# Altium schematic sheet Controller.SchDoc
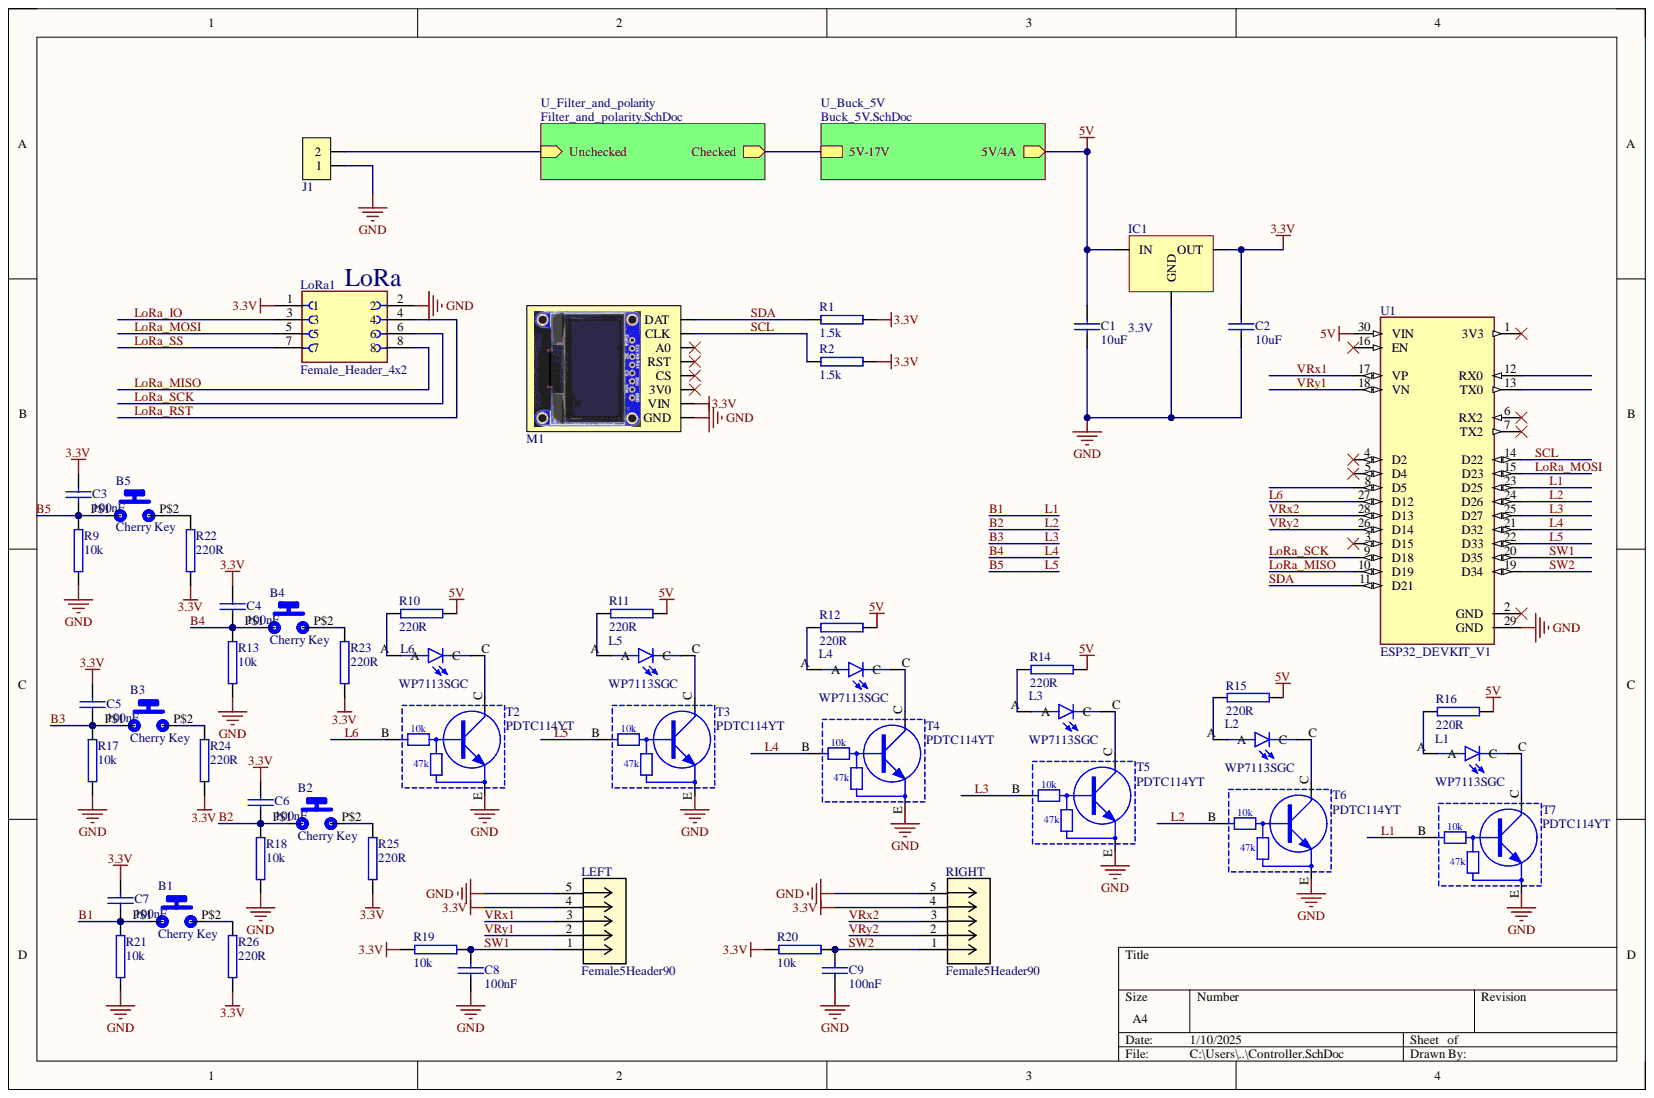
[diagram p0: Controller.SchDoc]

## Parts
B1  Cherry Key  MF: Cherry  [CHERRY_MX1A-11NN_SWITCH]
B2  Cherry Key  MF: Cherry  [CHERRY_MX1A-11NN_SWITCH]
B3  Cherry Key  MF: Cherry  [CHERRY_MX1A-11NN_SWITCH]
B4  Cherry Key  MF: Cherry  [CHERRY_MX1A-11NN_SWITCH]
B5  Cherry Key  MF: Cherry  [CHERRY_MX1A-11NN_SWITCH]
C1  value 10uF  [0603]  (hidden comment: C 10uF 0603)
C2  value 10uF  [0603]  (hidden comment: C 10uF 0603)
C3  value 100nF  [0603]  (hidden comment: C 100nF 0603)
C4  value 100nF  [0603]  (hidden comment: C 100nF 0603)
C5  value 100nF  [0603]  (hidden comment: C 100nF 0603)
C6  value 100nF  [0603]  (hidden comment: C 100nF 0603)
C7  value 100nF  [0603]  (hidden comment: C 100nF 0603)
C8  value 100nF  [0603]  (hidden comment: C 100nF 0603)
C9  value 100nF  [0603]  (hidden comment: C 100nF 0603)
IC1  Voltage Out: 3.3V  [SOT223]  (hidden comment: LDO_1A_3V3)
J1  2Pin_Female  [OSTTE020104]  (value not drawn)
L1  WP7113SGC  [LED_WP7113SGC]
L2  WP7113SGC  [LED_WP7113SGC]
L3  WP7113SGC  [LED_WP7113SGC]
L4  WP7113SGC  [LED_WP7113SGC]
L5  WP7113SGC  [LED_WP7113SGC]
L6  WP7113SGC  [LED_WP7113SGC]
LEFT  Female5Header90  [CONN_PPPC051LGB_SUL]
LoRa1  Female_Header_4x2  [SULLINS_PPPC042LFBN-RC]
M1  ADAFRUIT_938  [ADAFRUIT_938]  (value not drawn)
R1  value 1.5k  [0805]  (hidden comment: R 1K5 0805)
R2  value 1.5k  [0805]  (hidden comment: R 1K5 0805)
R9  value 10k  [0805]  (hidden comment: R 10K 0805)
R10  value 220R  [0805]  (hidden comment: R 220R 0805)
R11  value 220R  [0805]  (hidden comment: R 220R 0805)
R12  value 220R  [0805]  (hidden comment: R 220R 0805)
R13  value 10k  [0805]  (hidden comment: R 10K 0805)
R14  value 220R  [0805]  (hidden comment: R 220R 0805)
R15  value 220R  [0805]  (hidden comment: R 220R 0805)
R16  value 220R  [0805]  (hidden comment: R 220R 0805)
R17  value 10k  [0805]  (hidden comment: R 10K 0805)
R18  value 10k  [0805]  (hidden comment: R 10K 0805)
R19  value 10k  [0805]  (hidden comment: R 10K 0805)
R20  value 10k  [0805]  (hidden comment: R 10K 0805)
R21  value 10k  [0805]  (hidden comment: R 10K 0805)
R22  value 220R  [0805]  (hidden comment: R 220R 0805)
R23  value 220R  [0805]  (hidden comment: R 220R 0805)
R24  value 220R  [0805]  (hidden comment: R 220R 0805)
R25  value 220R  [0805]  (hidden comment: R 220R 0805)
R26  value 220R  [0805]  (hidden comment: R 220R 0805)
RIGHT  Female5Header90  [CONN_PPPC051LGB_SUL]
T2  PDTC114YT  [SOT-23]
T3  PDTC114YT  [SOT-23]
T4  PDTC114YT  [SOT-23]
T5  PDTC114YT  [SOT-23]
T6  PDTC114YT  [SOT-23]
T7  PDTC114YT  [SOT-23]
U1  ESP32_DEVKIT_V1  [MODULE_ESP32_DEVKIT_V1]

## Nets
3.3V: C2.1 C3.1 C4.1 C5.1 C6.1 C7.1 IC1.D LEFT.4 LoRa1.1 M1.2(VIN) R1.2 R2.2 R19.1 R20.1 R22.1 R23.1 R24.1 R25.1 R26.1 RIGHT.4
5V: C1.1 IC1.S R10.2 R11.2 R12.2 R14.2 R15.2 R16.2 U1.30(VIN)
GND: C1.2 C2.2 C8.2 C9.2 IC1.G J1.1 LEFT.5 LoRa1.2 M1.1(GND) R9.1 R13.1 R17.1 R18.1 R21.1 RIGHT.5 T2.E T3.E T4.E T5.E T6.E T7.E U1.29(GND)
B1: B1.P$1 C7.2 R21.2 T7.B U1.23(D25)
B2: B2.P$1 C6.2 R18.2 T6.B U1.24(D26)
B3: B3.P$1 C5.2 R17.2 T5.B U1.25(D27)
B4: B4.P$1 C4.2 R13.2 T4.B U1.21(D32)
B5: B5.P$1 C3.2 R9.2 T3.B U1.22(D33)
L6: T2.B U1.27(D12)
LoRa_IO: LoRa1.3
LoRa_MISO: LoRa1.8 U1.10(D19)
LoRa_MOSI: LoRa1.5 U1.15(D23)
LoRa_RST: LoRa1.4
LoRa_SCK: LoRa1.6 U1.9(D18)
LoRa_SS: LoRa1.7
SCL: M1.7(CLK) R2.1 U1.14(D22)
SDA: M1.8(DAT) R1.1 U1.11(D21)
SW1: C8.1 LEFT.1 R19.2 U1.20(D35)
SW2: C9.1 R20.2 RIGHT.1 U1.19(D34)
VRx1: LEFT.3 U1.17(VP)
VRx2: RIGHT.3 U1.28(D13)
VRy1: LEFT.2 U1.18(VN)
VRy2: RIGHT.2 U1.26(D14)
NetB1_P$2: B1.P$2 R26.2
NetB2_P$2: B2.P$2 R25.2
NetB3_P$2: B3.P$2 R24.2
NetB4_P$2: B4.P$2 R23.2
NetB5_P$2: B5.P$2 R22.2
NetJ1_2: J1.2
NetL1_A: L1.A R16.1
NetL1_C: L1.C T7.C
NetL2_A: L2.A R15.1
NetL2_C: L2.C T6.C
NetL3_A: L3.A R14.1
NetL3_C: L3.C T5.C
NetL4_A: L4.A R12.1
NetL4_C: L4.C T4.C
NetL5_A: L5.A R11.1
NetL5_C: L5.C T3.C
NetL6_A: L6.A R10.1
NetL6_C: L6.C T2.C
NetM1_3: M1.3(3V0)
NetM1_4: M1.4(CS)
NetM1_5: M1.5(RST)
NetM1_6: M1.6(A0)
NetU1_1: U1.1(3V3)
NetU1_2: U1.2(GND)
NetU1_3: U1.3(D15)
NetU1_4: U1.4(D2)
NetU1_5: U1.5(D4)
NetU1_6: U1.6(RX2)
NetU1_7: U1.7(TX2)
NetU1_8: U1.8(D5)
NetU1_12: U1.12(RX0)
NetU1_13: U1.13(TX0)
NetU1_16: U1.16(EN)
5V-17V:

## Library data of the parts
B1-B5 = Cherry Key: MX1A-11NN, Pushbutton Switch SPST-NO Keyswitch Panel Mount, Front; Availability: In Stock; Check_prices: https://www.snapeda.com/parts/MX1A-11NN/Cherry/view-part/?ref=eda; Component Kind: Standard; MP: MX1A-11NN; Package: None; Price: None; Purchase-URL: https://pricing.snapeda.com/search/part/MX1A-11NN/?ref=eda; SnapEDA_Link: https://www.snapeda.com/parts/MX1A-11NN/Cherry/view-part/?ref=snap; Library Name: FritzCustomComponets.IntLib
C1 C2 = C_10uF_0603: Database Table Name: Capacitors; TempKoeff: X5R; Tolerance: 10%; Voltage: 16V; Component Kind: Standard; Part ID: C_10uF_0603; Price 1pc (CHF): 0.40; Signal Integrity: SignalIntegrity; Simulation: Model Name; Supplier 1: Digi-Key; Supplier Part Number 1: 490-12317-1-ND; Library Name: FHGR_Altium_database.DbLib
C3-C9 = C_100nF_0603: Database Table Name: Capacitors; TempKoeff: Y5V; Tolerance: -20%/+80%; Voltage: 50V; Component Kind: Standard; Part ID: C_100nF_0603; Price 1pc (CHF): 0.1; Signal Integrity: SignalIntegrity; Simulation: Model Name; Supplier 1: Digi-Key; Supplier Part Number 1: 1276-1012-1-ND; Library Name: FHGR_Altium_database.DbLib
IC1 = NCP1117LP: IC NCP1117LP LDO; Current: 1A; Database Table Name: Power; Voltage Max: 18V; Component Kind: Standard; ComponentLink1Description: Datasheet; ComponentLink1URL: https://www.onsemi.com/pdf/datasheet/ncp1117lp-d.pdf; Part ID: NCP1117LP; Price 10pcs: 0.34; Supplier 1: Digi-Key; Supplier Part Number 1: NCP1117LPST33T3GOSCT-ND; Library Name: FHGR_Altium_database.DbLib
J1 = Terminal_2Pin: Database Table Name: Connectors; Component Kind: Standard; ComponentLink1Description: Datasheet; ComponentLink1URL: https://www.on-shore.com/wp-content/uploads/OSTTEXX0104.pdf; Part ID: Terminal_2Pin; Price 1pc (CHF): 0.69; Supplier 1: Digi-Key; Supplier Part Number 1: ED2740-ND; Library Name: FHGR_Altium_database.DbLib
L1-L6 = WP7113SGC: Green 568nm LED Indication - Discrete 2.2V Radial; MF: Kingbright; Availability: Unavailable; Component Kind: Standard; MP: WP7113SGC; Package: Radial Kingbright; Price: None; SnapEDA_Link: https://www.snapeda.com/parts/WP7113SGC/Kingbright/view-part/523411/?ref=snap; Library Name: FritzCustomComponets.IntLib
LEFT RIGHT = Female5Header90: Mfr_Name: Sullins; Component Kind: Standard; Description: Female5Header90; RefDes: RefDes; Type: Type; Library Name: FritzCustomComponets.IntLib
LoRa1 = Female_Header_4x2: 8 Position Header Connector 0.100 (2.54mm) Through Hole Gold; MF: Sullins Connector Solutions; MP: PPPC042LFBN-RC; Availability: In Stock; Check_prices: https://www.snapeda.com/parts/PPPC042LFBN-RC/Sullins+Connector+Solutions/view-part/?ref=eda; Component Kind: Standard; Package: None; Price: None; Purchase-URL: https://pricing.snapeda.com/search/part/PPPC042LFBN-RC/?ref=eda; SnapEDA_Link: https://www.snapeda.com/parts/PPPC042LFBN-RC/Sullins+Connector+Solutions/view-part/?ref=snap; Library Name: FritzCustomComponets.IntLib
M1 = ADAFRUIT_938: 128x64 OLED Display; Buchse: SAM1213-08-ND; Database Table Name: Modules; Stecker: 732-5321-ND; Component Kind: Standard; ComponentLink1Description: Datasheet; ComponentLink1URL: https://media.digikey.com/pdf/Data%20Sheets/Adafruit%20PDFs/938_Web.pdf; Part ID: ADAFRUIT_938; Price 1pc (CHF): 18.15; Supplier 1: Digi-Key; Supplier Part Number 1: 1528-1512-ND; Library Name: FHGR_Altium_database.DbLib
R1 R2 = R_1k5_0805: Database Table Name: Resistors; Power Dissipation: 250mW; Tolerance: 1%; Component Kind: Standard; Part ID: R_1k5_0805; Price 10pcs (CHF): 0.072; Signal Integrity: SignalIntegrity; Simulation: Model Name; Supplier 1: Digi-Key; Supplier Part Number 1: RNCP0805FTD1K50CT-ND; Library Name: FHGR_Altium_database.DbLib
R9 R13 R17-R21 = R_10k_0805: Database Table Name: Resistors; Power Dissipation: 125mW; Tolerance: 1%; Component Kind: Standard; Part ID: R_10k_0805; Price 10pcs (CHF): 0.021; Signal Integrity: SignalIntegrity; Simulation: Model Name; Supplier 1: Digi-Key; Supplier Part Number 1: 311-10.0KCRCT-ND; Library Name: FHGR_Altium_database.DbLib
R10-R12 R14-R16 R22-R26 = R_220R_0805: Database Table Name: Resistors; Power Dissipation: 125mW; Tolerance: 1%; Component Kind: Standard; Part ID: R_220R_0805; Price 10pcs (CHF): 0.052; Signal Integrity: SignalIntegrity; Simulation: Model Name; Supplier 1: Digi-Key; Supplier Part Number 1: A129741CT-ND; Library Name: FHGR_Altium_database.DbLib
T2-T7 = T_PDTC114YT: Current: 100mA; Database Table Name: Transistors; Frequency: 230MHz; Power Dissipation: 250mW; Voltage: 50V; Component Kind: Standard; ComponentLink1Description: Datasheet; ComponentLink1URL: https://assets.nexperia.com/documents/data-sheet/PDTC114Y_SER.pdf; Part ID: T_PDTC114YT; Price 1pc (CHF): 0.18; Supplier 1: Digi-Key; Supplier Part Number 1: 1727-5134-6-ND; Library Name: FHGR_Altium_database.DbLib
U1 = ESP32_DEVKIT_V1: Dual core, Wi-Fi: 2.4 GHz up to 150 Mbits/s,BLE (Bluetooth Low Energy) and legacy Bluetooth, 32 bits, Up to 240 MHz; MF: Do it; MP: ESP32-DEVKIT-V1; Availability: Not in stock; Check_prices: https://www.snapeda.com/parts/ESP32-DEVKIT-V1/Do+it/view-part/?ref=eda; Component Kind: Standard; Package: None; Price: None; SnapEDA_Link: https://www.snapeda.com/parts/ESP32-DEVKIT-V1/Do+it/view-part/?ref=snap; Library Name: FritzCustomComponets.IntLib
